# Altium schematic sheet RFID_MEMMORY.SchDoc
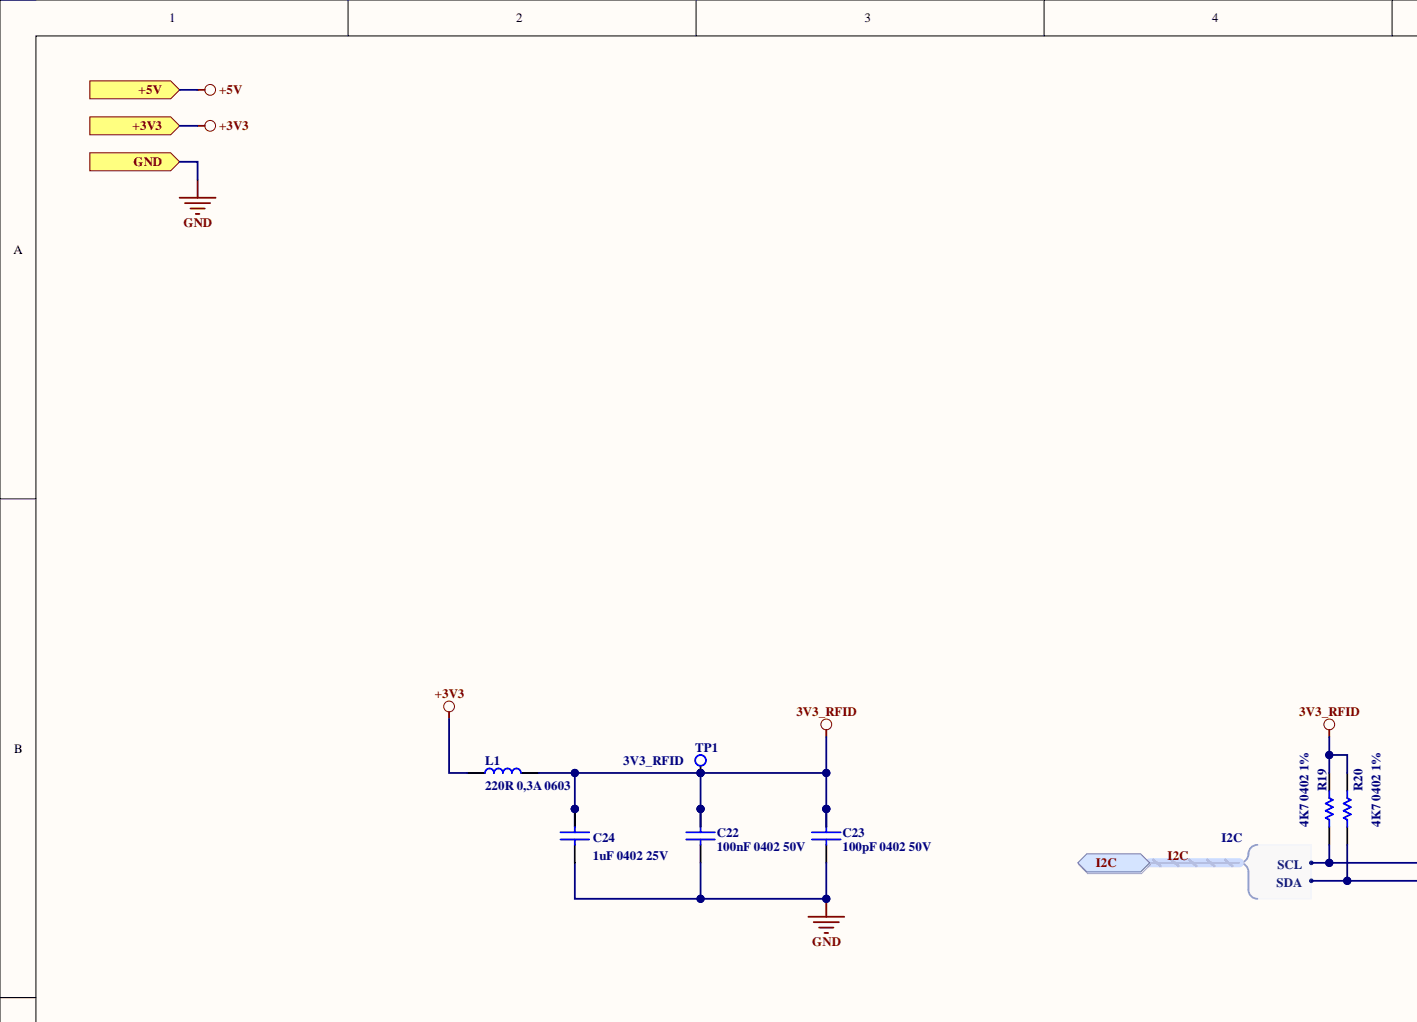
[diagram p0: RFID_MEMMORY.SchDoc, top left]
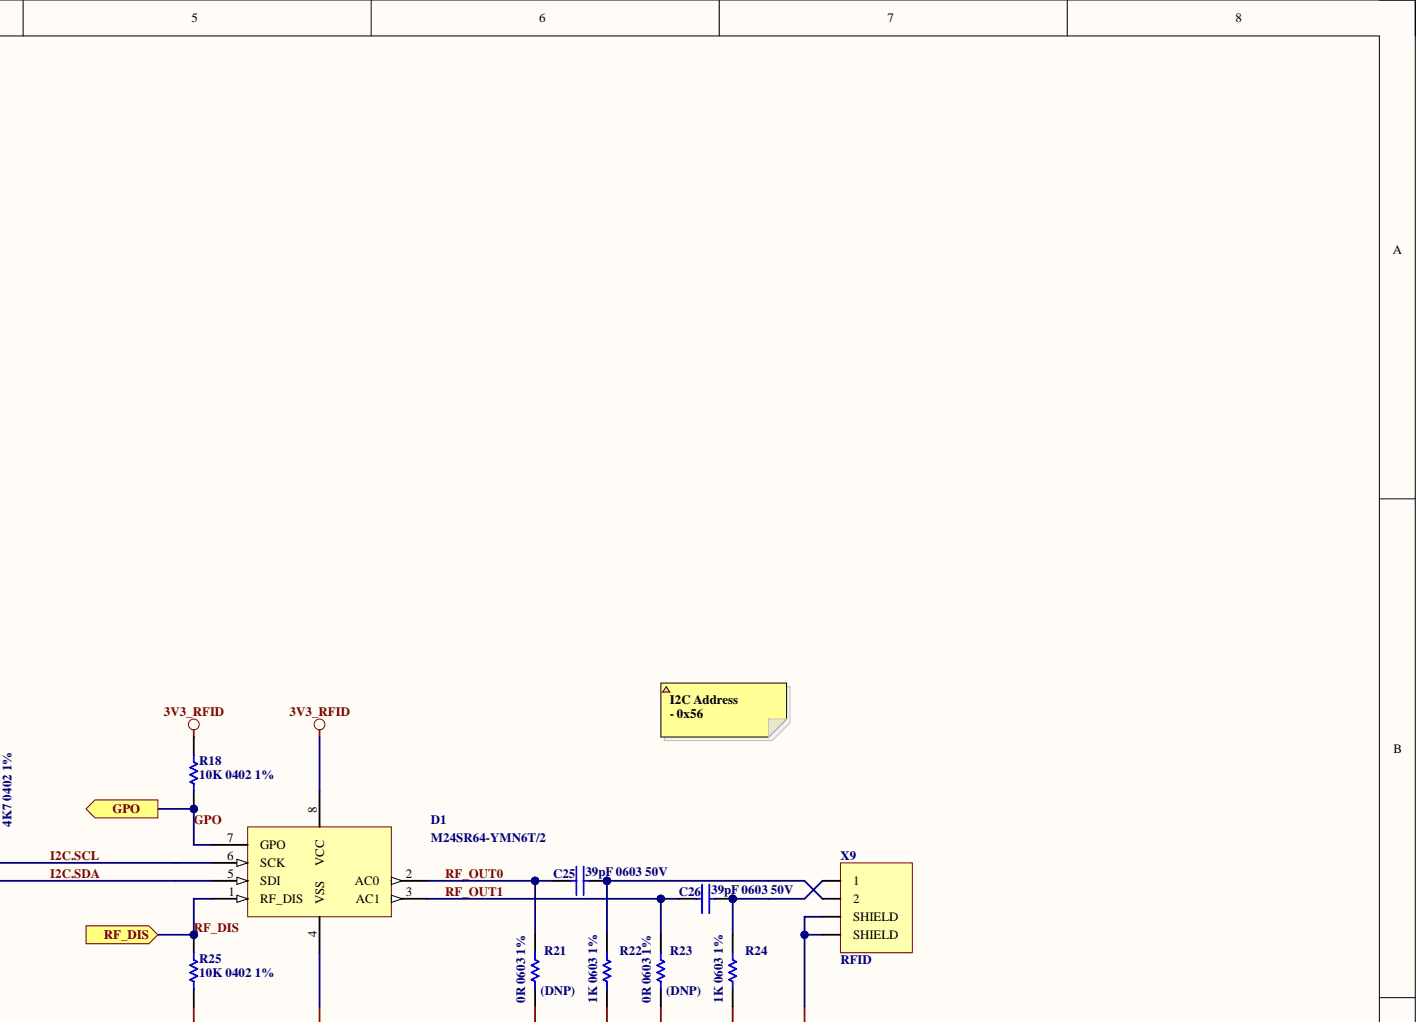
[diagram p1: RFID_MEMMORY.SchDoc, top right]
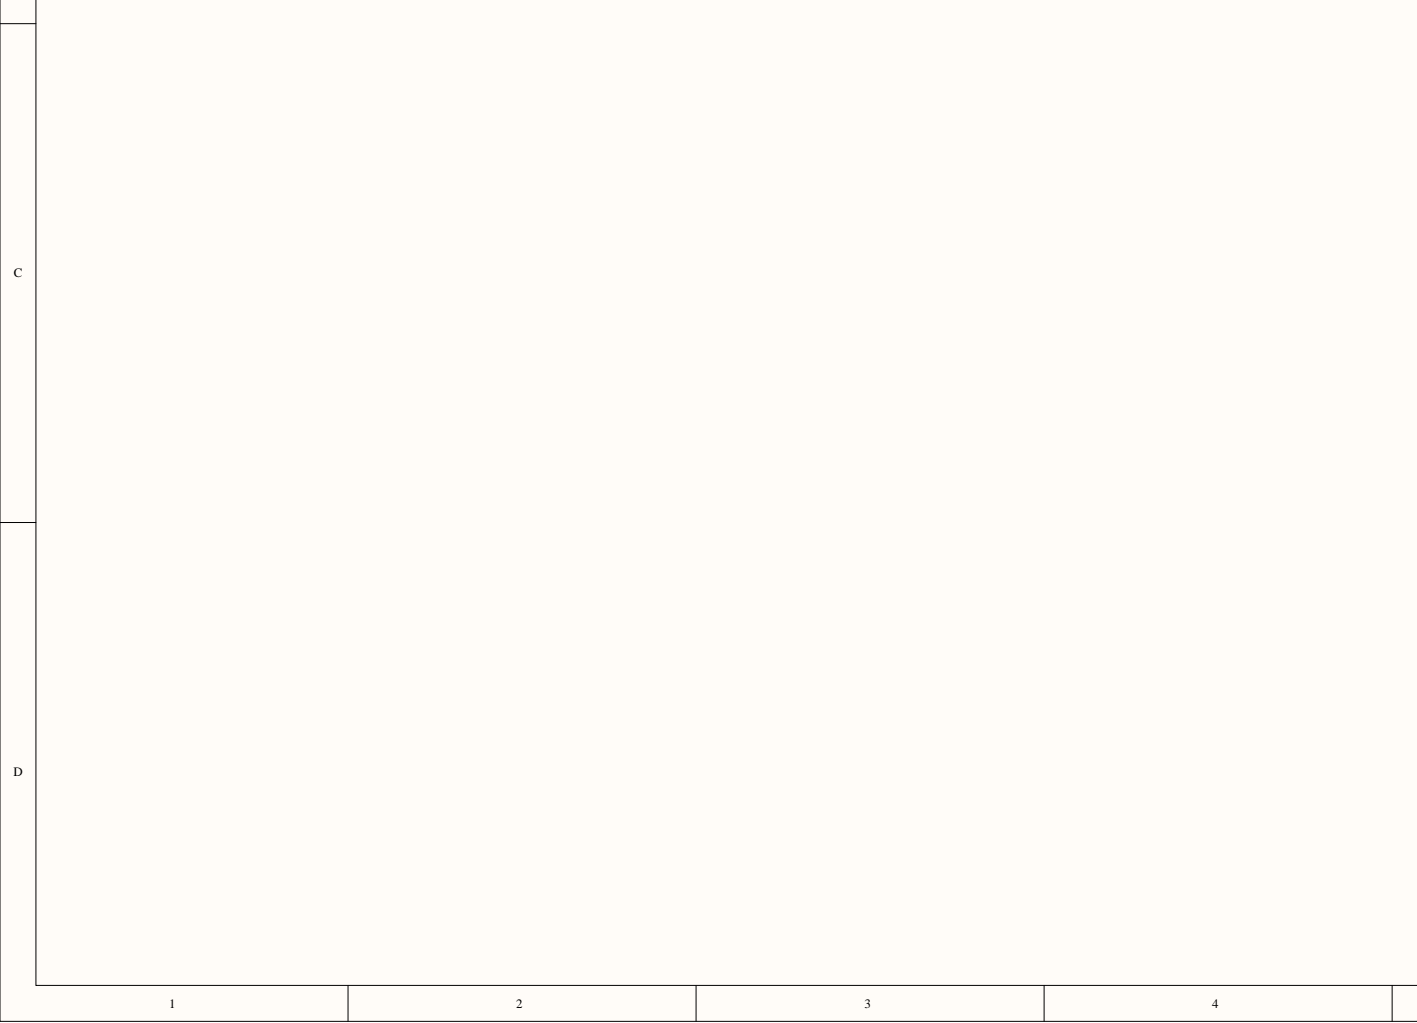
[diagram p2: RFID_MEMMORY.SchDoc, bottom left]
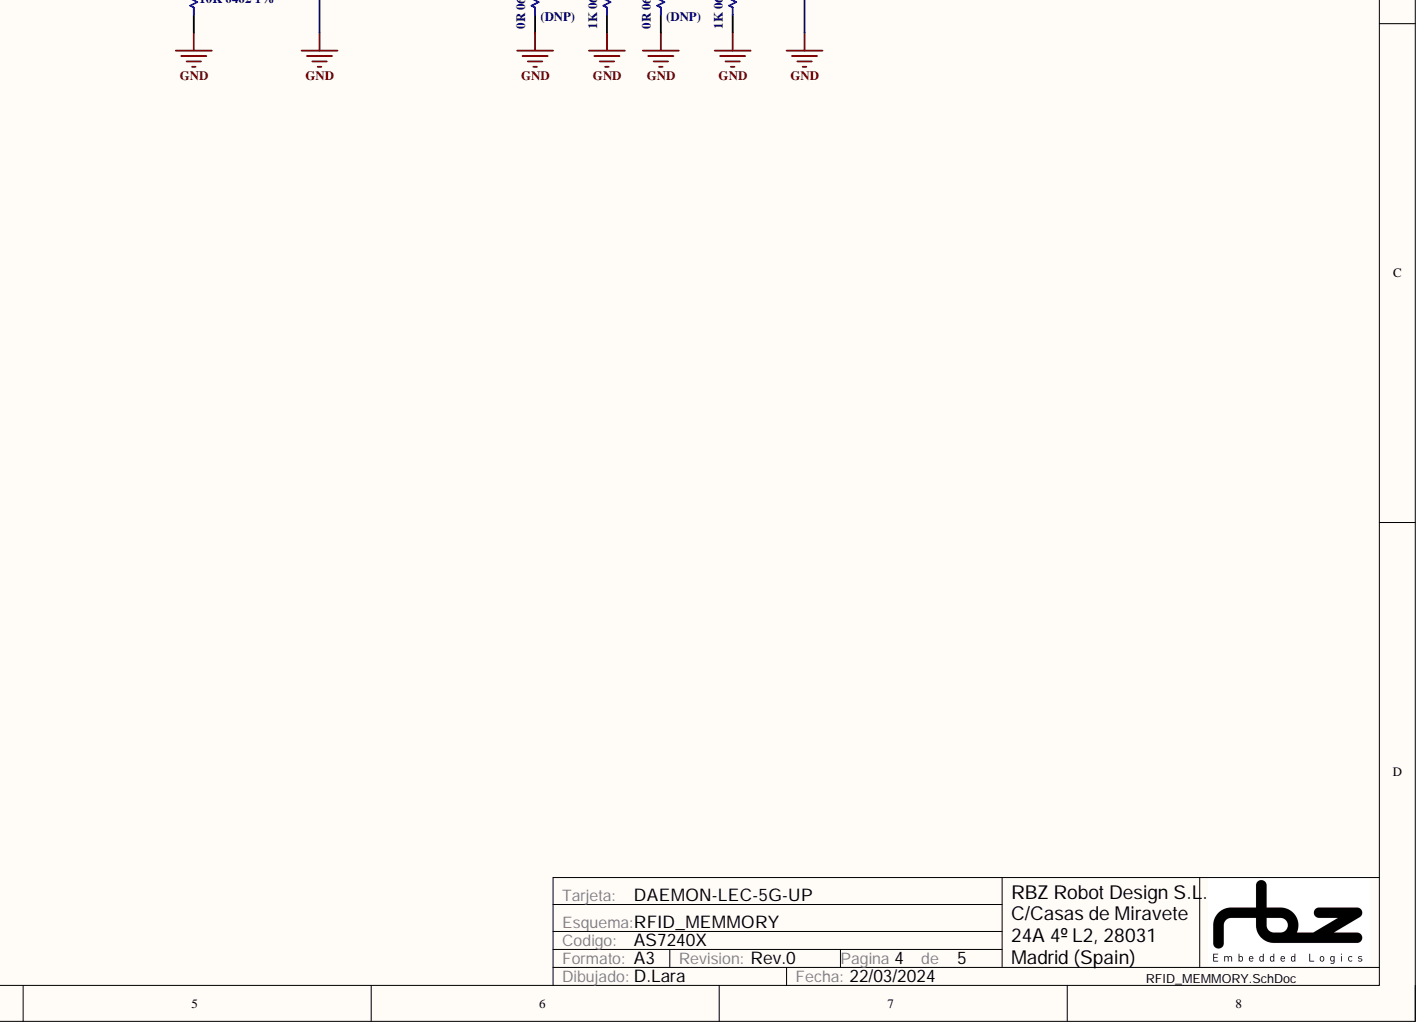
[diagram p3: RFID_MEMMORY.SchDoc, bottom right]

## Parts
C22  100nF 0402 50V  [C0402(1005)-HIGH_DENSITY]
C23  100pF 0402 50V  [C0402(1005)-HIGH_DENSITY]
C24  1uF 0402 25V  [C0402(1005)-HIGH_DENSITY]
C25  39pF 0603 50V  [C0603(1608)-HIGH_DENSITY]
C26  39pF 0603 50V  [C0603(1608)-HIGH_DENSITY]
D1  M24SR64-YMN6T/2  [SO8N]
L1  220R 0,3A 0603  [L0603(1608) - High_Density]
R18  10K 0402 1%  [R0402(1005)-HIGH_DENSITY]
R19  4K7 0402 1%  [R0402(1005)-HIGH_DENSITY]
R20  4K7 0402 1%  [R0402(1005)-HIGH_DENSITY]
R21  0R 0603 1%  Montaje: (DNP)  [R0603(1608)-HIGH_DENSITY]
R22  1K 0603 1%  [R0603(1608)-HIGH_DENSITY]
R23  0R 0603 1%  Montaje: (DNP)  [R0603(1608)-HIGH_DENSITY]
R24  1K 0603 1%  [R0603(1608)-HIGH_DENSITY]
R25  10K 0402 1%  [R0402(1005)-HIGH_DENSITY]
TP1  3V3_RFID  [PAD_0.8mm_Round_Multilayer]  (no BOM)
X9  RFID  [MOLEX_5055680271]

## Ports
+3V3, +5V, GND, GPO, I2C, I2C.SCL, I2C.SDA, RF_DIS

## Nets
3V3_RFID: C22.1 C23.2 C24.2 D1.8(VCC) L1.1 L1.2 R18.2 R19.2 R20.2 TP1.1 ; port +3V3
GND: C22.2 C23.1 C24.1 D1.4(VSS) R21.1 R22.1 R23.1 R24.1 R25.1 X9.S1(SHIELD) X9.S2(SHIELD) ; port GND
GPO: D1.7(GPO) R18.1 ; port GPO
I2C.SCL: D1.6(SCK) R19.1 ; port I2C
I2C.SDA: D1.5(SDI) R20.1 ; port I2C
RF_DIS: D1.1(RF_DIS) R25.2 ; port RF_DIS
RF_OUT0: C25.1 D1.2(AC0) R21.2
RF_OUT1: C26.1 D1.3(AC1) R23.2
NetC25_2: C25.2 R22.2 X9.2
NetC26_2: C26.2 R24.2 X9.1
+5V: ; port +5V

## Library data of the parts
C22 = CC0402X7RK050V100N: Condensador ceramico genérico 0402 ±10% X7R -55/125°C 50V 100nF; Fabricante: Murata; Inspected: False; Precio: 0,09€; Precio Prod.: 0,009€ @1000; Ref. Catalogo: (D) 490-10700-1-ND; Referencia: GRM155R71H104KE14D; Type: Capacitor; Codigo RBZ: CC0402X7RK050V100N; Component Kind: Standard; Distribuidor: -; Halogen Free: -; Library Name: Capacitor_Generic_Ceramic.SchLib; Montaje: -; REACH: -; RoHS: True; Value: 100nF 0402 50V; HelpURL: S:\Condensadores\Datasheets\Murata c02e.pdf
C23 = CC0402C0GJ050V100P: Condensador cerámico genérico 0402 ±5% COG -55/125º 50V 100pF; Fabricante: Murata; Inspected: True; Precio: 0,09€; Precio Prod.: 0,015€ @1000; Ref. Catalogo: (D) 490-10669-1-ND; Referencia: GCM1555C1H101JA16D; Type: Capacitor; Codigo RBZ: CC0402C0GJ050V100P; Component Kind: Standard; Distribuidor: -; Halogen Free: -; Library Name: Capacitor_Generic_Ceramic.SchLib; Montaje: -; REACH: -; RoHS: True; Value: 100pF 0402 50V; HelpURL: S:\Condensadores\Datasheets\Murata c02e.pdf
C24 = CC0402X5RK025V001U: Condensador ceramico genérico 0402 ±10% X5R -105/85°C 25V 1uF; Fabricante: Murata; Inspected: False; Precio: 0,09€; Precio Prod.: 0,017€ @1000; Ref. Catalogo: (D) 490-10017-1-ND; Referencia: GRM155R61E105KA12D; Type: Capacitor; Codigo RBZ: CC0402X5RK025V001U; Component Kind: Standard; Distribuidor: -; Halogen Free: -; Library Name: Capacitor_Generic_Ceramic.SchLib; Montaje: -; REACH: -; RoHS: True; Value: 1uF 0402 25V; HelpURL: S:\Condensadores\Datasheets\Murata_c02e.pdf
C25 C26 = CC0603C0GJ050V039P: Condensador cerámico genérico 0603 ±5% COG -55/125º 50V 39pF; Fabricante: Murata Electronics; Inspected: True; Precio: 0,09€; Ref. Catalogo: (D) 490-11326-2-ND; Referencia: GRM0335C1H390JA01D; Type: Capacitor; Codigo RBZ: CC0603C0GJ050V039P; Component Kind: Standard; Distribuidor: -; Halogen Free: -; Library Name: Capacitor_Generic_Ceramic.SchLib; Montaje: -; REACH: -; RoHS: True; Value: 39pF 0603 50V; HelpURL: S:\Condensadores\Datasheets\GRM0335C1H390JA01-01.pdf
D1 = M24SR64-YMN6T/2: RFID Transponder IC 13.56MHz ISO 14443, NFC I2C 2.7V ~ 5.5V 8-SOIC (0.154", 3.90mm Width); Fabricante: ST; Precio: 2.11 €; Ref. Catalogo: (D) 497-14344-2-ND; Component Kind: Standard; Distribuidor: -; Montaje: -; Referencia: M24SR64-YMN6T/2; Value: RFID Transponder IC 13.56MHz ISO 14443, NFC I2C 2.7V ~ 5.5V 8-SOIC (0.154", 3.90mm Width); HelpURL: S:\ST\datasheets\en.DM00067892.pdf; Library Name: ST RFID_Reader.SchLib
L1 = BLM18RK221SN1D: FERRITE BEAD 220 OHM 0603 1LN; Codigo RBZ: MUR_BLM18RK221SN1D; Fabricante: Murata; Inspected: False; Precio: 0,09€; Precio Prod.: 0,023€ @1000; Ref. Catalogo: (D) 490-5985-1-ND; Type: Choke; Component Kind: Standard; Distribuidor: -; Halogen Free: -; Library Name: Choke EMI.SchLib; Montaje: -; REACH: -; Referencia: BLM18RK221SN1D; RoHS: True; Value: 220R 0,3A 0603; HelpURL: S:\bobinas\datasheets\Murata_ENFA0003-1.pdf
R18 R25 = R0402F010K: Resistencia genérica 0402 1% 10K; Fabricante: Vishay; Inspected: True; Precio: 0,08€; Precio Prod.: 0,0041€ @1000; Ref. Catalogo: (D) 541-3959-1-ND; Referencia: CRCW040210K0FKEDC; Codigo RBZ: R0402F010K; Component Kind: Standard; Distribuidor: -; Halogen Free: -; Library Name: Resistor_Generic.SchLib; Montaje: -; REACH: -; RoHS: True; Type: Resistor; Value: 10K 0402 1%; HelpURL: S:\Resistencias\Datasheets\Vishay_crcwce3.pdf
R19 R20 = R0402F04K7: Resistencia genérica 0402 1% 4K7; Fabricante: Vishay; Inspected: True; Precio: 0,08€; Precio Prod.: 0,0041€ @1000; Ref. Catalogo: (D) 541-3963-1-ND; Referencia: CRCW04024K70FKEDC; Codigo RBZ: R0402F04K7; Component Kind: Standard; Distribuidor: -; Halogen Free: -; Library Name: Resistor_Generic.SchLib; Montaje: -; REACH: -; RoHS: True; Type: Resistor; Value: 4K7 0402 1%; HelpURL: S:\Resistencias\Datasheets\Vishay_crcwce3.pdf
R21 R23 = R0603F000R: Resistencia genérica 0603 1% 0R; Fabricante: Vishay; Inspected: True; Precio: 0,08€; Precio Prod.: 0,006€ @1000; Ref. Catalogo: (D) 541-4013-1-ND; Referencia: CRCW06030000Z0EBC; Codigo RBZ: R0603F000R; Component Kind: Standard; Distribuidor: -; Halogen Free: -; Library Name: Resistor_Generic.SchLib; REACH: -; RoHS: True; Type: Resistor; Value: 0R 0603 1%; HelpURL: S:\Resistencias\Datasheets\VIS_crcw.pdf
R22 R24 = R0603F001K: Resistencia genérica 0603 1% 1K; Fabricante: Vishay; Inspected: False; Precio: 0,08€; Precio Prod.: 0,006€ @1000; Ref. Catalogo: (D) 541-1.00KHCT-ND; Referencia: CRCW06031K00FKEA; Codigo RBZ: R0603F001K; Component Kind: Standard; Distribuidor: -; Halogen Free: -; Library Name: Resistor_Generic.SchLib; Montaje: -; REACH: -; RoHS: True; Type: Resistor; Value: 1K 0603 1%; HelpURL: S:\Resistencias\Datasheets\Vishay_crcwce3.pdf
TP1 = TestPoint_Pad_Multilayer: TestPoint Pad; Codigo RBZ: COMP_IN_PCB; Fabricante: PCB; Inspected: True; Value: TestPoint_Pad_SMD; Distribuidor: -; Halogen Free: True; HelpURL: -; Library Name: TestPoints.SchLib; Montaje: -; Precio: -; Precio Prod.: -; REACH: -; Ref. Catalogo: -; Referencia: -; RoHS: True; Serigrafia: 3V3_RFID; Type: Test_Point
X9 = 5055680271: CONN HEADER SMD 2POS 1.25MM; Codigo RBZ: MOL_5037630491; Fabricante: Molex; Inspected: False; Precio: 0,72€; Ref. Catalogo: (D) WM17033TR-ND; Value: Conn Header Surface Mount, Right Angle 4 p 1.00mm; Component Kind: Standard; Distribuidor: -; Halogen Free: -; Library Name: Molex.SchLib; Montaje: -; REACH: -; Referencia: 5055680271; RoHS: -; Serigrafia: RFID; Type: Connector; helpURL: S:\Conectores\datasheets\987651-4888.pdf
